# Altium schematic sheet upper-pcb.SchDoc(upper-pcb)
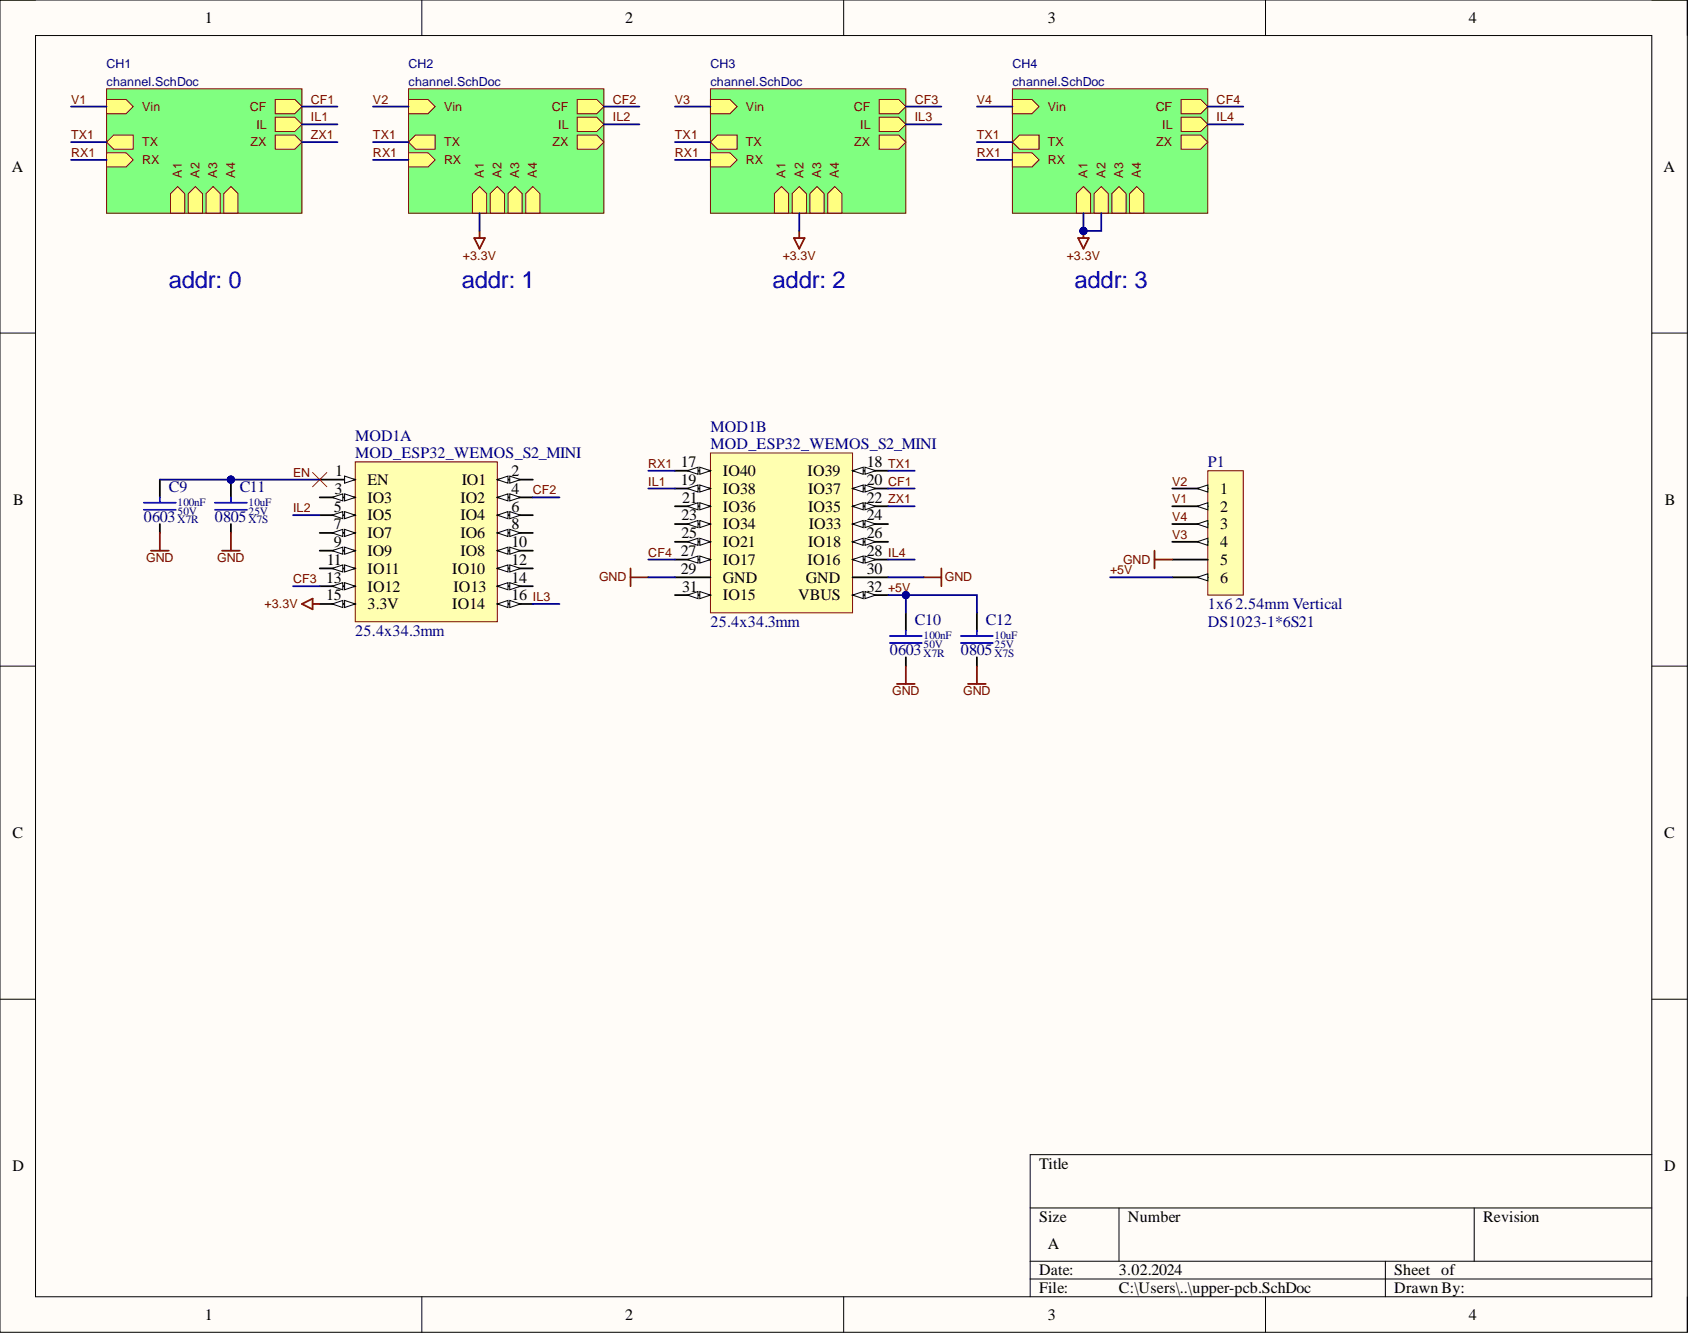
[diagram p0: upper-pcb.SchDoc]

## Parts
C9  value 100nF  Dielectric: X7R  SCH_Footprint: 0603  Voltage: 50V  [C_0603_1608]  (hidden comment: Ceramic X7R)
C10  value 100nF  Dielectric: X7R  SCH_Footprint: 0603  Voltage: 50V  [C_0603_1608]  (hidden comment: Ceramic X7R)
C11  value 10uF  Dielectric: X7S  SCH_Footprint: 0805  Voltage: 25V  [C_0805_2012]  (hidden comment: Ceramic X7S)
C12  value 10uF  Dielectric: X7S  SCH_Footprint: 0805  Voltage: 25V  [C_0805_2012]  (hidden comment: Ceramic X7S)
MOD1  value MOD_ESP32_WEMOS_S2_MINI  SCH_Footprint: 25.4x34.3mm  [MOD_ESP32_WEMOS_S2_MINI]  (hidden comment: ESP32-S2FN4R2 mini wifi board)  (parts A,B)
P1  value DS1023-1*6S21  Manufacturer Part Number: DS1023-1*6S21  [J_HEADER_1x6_2.54_VERTICAL]  (hidden comment: Goldpin female straight)

## Nets
+3.3V: MOD1.15(3.3V)
GND: C9.2 C10.2 C11.2 C12.2 MOD1.29(GND) MOD1.30(GND) P1.5
+5V: C10.1 C12.1 MOD1.32(VBUS) P1.6
CF1: MOD1.20(IO37)
CF2: MOD1.4(IO2)
CF3: MOD1.13(IO12)
CF4: MOD1.27(IO17)
EN: C9.1 C11.1 MOD1.1(EN)
IL1: MOD1.19(IO38)
IL2: MOD1.5(IO5)
IL3: MOD1.16(IO14)
IL4: MOD1.28(IO16)
RX1: MOD1.17(IO40)
TX1: MOD1.18(IO39)
V1: P1.2
V2: P1.1
V3: P1.4
V4: P1.3
ZX1: MOD1.22(IO35)
NetMOD1_2: MOD1.2(IO1)
NetMOD1_3: MOD1.3(IO3)
NetMOD1_6: MOD1.6(IO4)
NetMOD1_7: MOD1.7(IO7)
NetMOD1_8: MOD1.8(IO6)
NetMOD1_9: MOD1.9(IO9)
NetMOD1_10: MOD1.10(IO8)
NetMOD1_11: MOD1.11(IO11)
NetMOD1_12: MOD1.12(IO10)
NetMOD1_14: MOD1.14(IO13)
NetMOD1_21: MOD1.21(IO36)
NetMOD1_23: MOD1.23(IO34)
NetMOD1_24: MOD1.24(IO33)
NetMOD1_25: MOD1.25(IO21)
NetMOD1_26: MOD1.26(IO18)
NetMOD1_31: MOD1.31(IO15)
A1:
A2:
A3:
A4:
ZX:

## Library data of the parts
C9 C10 = C_100nF_50V_X7R_0603_5: Capacitor; Manufacturer: Samsung; Manufacturer Part Number: CL10B104JB8NNNC; Database Table Name: Capacitors; Tolerance (%): 5; Component Kind: Standard; HelpURL: http://www.tme.eu/pl/Document/4a42202b32dab16128fe107dd69598cc/samsung-chip-cap.pdf; Library Name: Library.DBLib; Part Number: C_100nF_50V_X7R_0603_5; Supplier 1: TME; Supplier 2: RS Components; Supplier 3: Farnell; Supplier 4: Mouser; Supplier 5: Digi-Key; Supplier 6: LCSC; Supplier Part Number 1: CL10B104JB8NNNC; Supplier Part Number 2: 7665455; Supplier Part Number 5: 1276-1033-1-ND; Supplier Part Number 6: C24452
C11 C12 = C_10uF_25V_X7S_0805_10: Capacitor; Manufacturer: Murata; Manufacturer Part Number: GRT21BC71E106KE13L; Database Table Name: Capacitors; Tolerance (%): 10; Component Kind: Standard; HelpURL: https://eu.mouser.com/datasheet/2/281/1/GRT21BC71E106KE13_01A-3158174.pdf; Library Name: Library.DBLib; Part Number: C_10uF_25V_X7S_0805_10; Supplier 1: TME; Supplier 2: RS Components; Supplier 3: Farnell; Supplier 4: Mouser; Supplier 5: Digi-Key; Supplier 6: LCSC; Supplier Part Number 1: GRT21BC71E106KE13L; Supplier Part Number 2: 2478205; Supplier Part Number 3: 3790456; Supplier Part Number 4: 81-GRT21BC71E106KE13; Supplier Part Number 5: 490-GRT21BC71E106KE13LCT-ND
MOD1 = MOD_ESP32_WEMOS_S2_MINI: Manufacturer: Wemos; Database Table Name: Modules; Component Kind: Standard; HelpURL: https://www.wemos.cc/en/latest/s2/s2_mini.html; Library Name: Library.DBLib; Manufacturer Part Number: MOD_ESP32_WEMOS_S2_MINI; Part Number: MOD_ESP32_WEMOS_S2_MINI; Supplier 1: TME; Supplier 2: RS Components; Supplier 3: Farnell; Supplier 4: Mouser; Supplier 5: Digi-Key; Supplier 6: LCSC; Supplier 7: Aliexpress; Supplier Part Number 7: https://pl.aliexpress.com/item/1005003145192016.html
P1 = J_HEADER_1x6_2.54_VERTICAL: Pin Header; Manufacturer: Connfly; Database Table Name: Connectors; SCH_Footprint: 1x6 2.54mm Vertical; Component Kind: Standard; HelpURL: https://www.tme.eu/Document/584b1a60cf2e8809a936c5f5ff2de0d5/zl262-sg.pdf; Library Name: Library.DBLib; Part Number: J_HEADER_1x6_2.54_VERTICAL; Supplier 1: TME; Supplier 2: RS Components; Supplier 3: Farnell; Supplier 4: Mouser; Supplier 5: Digi-Key; Supplier 6: LCSC; Supplier Part Number 1: ZL262-6SG
